# Altium schematic sheet WIFI.SchDoc
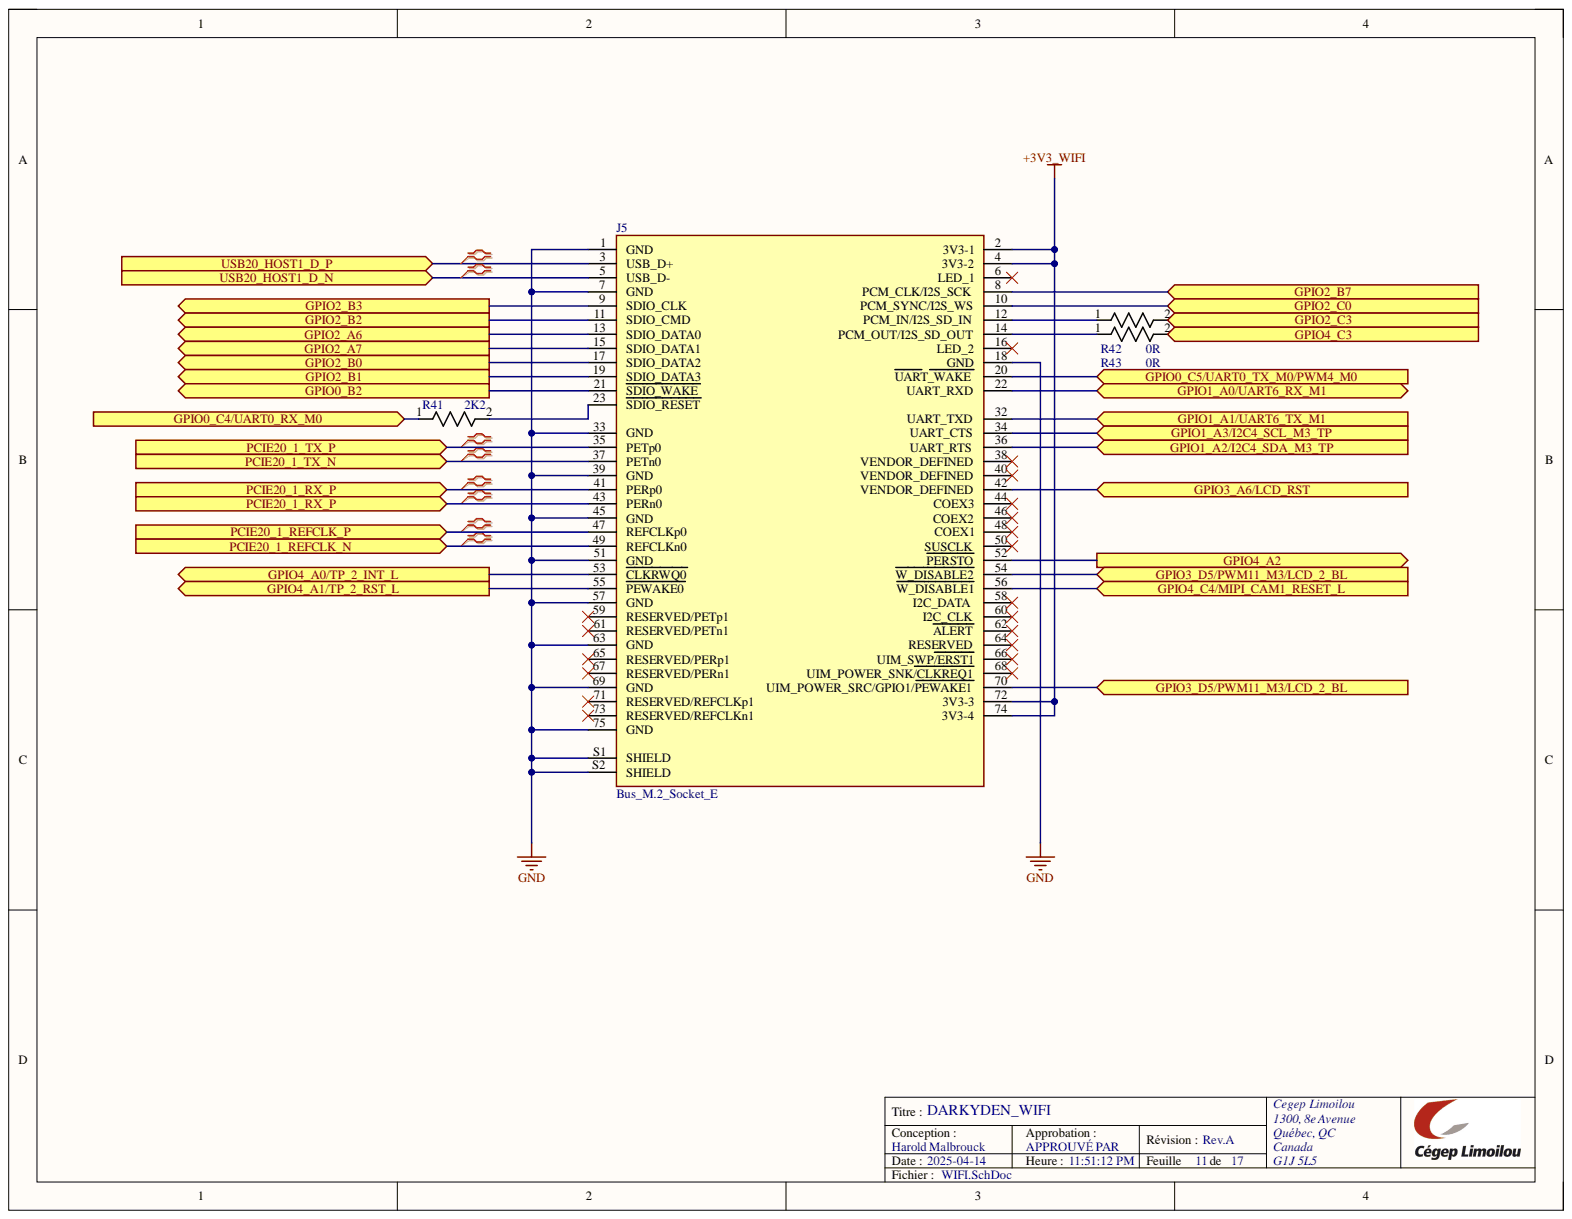
[diagram p0: WIFI.SchDoc]

## Parts
J5  Bus_M.2_Socket_E  [AMPHENOL_MDT320E01001]
R41  2K2  [RES_0816_SUS]
R42  0R  [RES_0816_SUS]
R43  0R  [RES_0816_SUS]

## Ports
GPIO0_B2, GPIO0_C4/UART0_RX_M0, GPIO0_C5/UART0_TX_M0/PWM4_M0, GPIO1_A0/UART6_RX_M1, GPIO1_A1/UART6_TX_M1, GPIO1_A2/I2C4_SDA_M3_TP, GPIO1_A3/I2C4_SCL_M3_TP, GPIO2_A6, GPIO2_A7, GPIO2_B0, GPIO2_B1, GPIO2_B2, GPIO2_B3, GPIO2_B7, GPIO2_C0, GPIO2_C3, GPIO3_A6/LCD_RST, GPIO3_D5/PWM11_M3/LCD_2_BL, GPIO4_A0/TP_2_INT_L, GPIO4_A1/TP_2_RST_L, GPIO4_A2, GPIO4_C3, GPIO4_C4/MIPI_CAM1_RESET_L, PCIE20_1_REFCLK_N, PCIE20_1_REFCLK_P, PCIE20_1_RX_P, PCIE20_1_TX_N, PCIE20_1_TX_P, USB20_HOST1_D_N, USB20_HOST1_D_P

## Nets
+3V3_WIFI: J5.2(3V3-1) J5.4(3V3-2) J5.72(3V3-3) J5.74(3V3-4)
GND: J5.1(GND) J5.7(GND) J5.18(GND) J5.33(GND) J5.39(GND) J5.45(GND) J5.51(GND) J5.57(GND) J5.63(GND) J5.69(GND) J5.75(GND) J5.S1(SHIELD) J5.S2(SHIELD)
GPIO0_B2: J5.21(SDIO_WAKE) ; port GPIO0_B2
GPIO0_C4/UART0_RX_M0: R41.1 ; port GPIO0_C4/UART0_RX_M0
GPIO0_C5/UART0_TX_M0/PWM4_M0: J5.20(UART_WAKE) ; port GPIO0_C5/UART0_TX_M0/PWM4_M0
GPIO1_A0/UART6_RX_M1: J5.22(UART_RXD) ; port GPIO1_A0/UART6_RX_M1
GPIO1_A1/UART6_TX_M1: J5.32(UART_TXD) ; port GPIO1_A1/UART6_TX_M1
GPIO1_A2/I2C4_SDA_M3_TP: J5.36(UART_RTS) ; port GPIO1_A2/I2C4_SDA_M3_TP
GPIO1_A3/I2C4_SCL_M3_TP: J5.34(UART_CTS) ; port GPIO1_A3/I2C4_SCL_M3_TP
GPIO2_A6: J5.13(SDIO_DATA0) ; port GPIO2_A6
GPIO2_A7: J5.15(SDIO_DATA1) ; port GPIO2_A7
GPIO2_B0: J5.17(SDIO_DATA2) ; port GPIO2_B0
GPIO2_B1: J5.19(SDIO_DATA3) ; port GPIO2_B1
GPIO2_B2: J5.11(SDIO_CMD) ; port GPIO2_B2
GPIO2_B3: J5.9(SDIO_CLK) ; port GPIO2_B3
GPIO2_B7: J5.8(PCM_CLK/I2S_SCK) ; port GPIO2_B7
GPIO2_C0: J5.10(PCM_SYNC/I2S_WS) ; port GPIO2_C0
GPIO2_C3: R42.2 ; port GPIO2_C3
GPIO3_A6/LCD_RST: J5.42(VENDOR_DEFINED) ; port GPIO3_A6/LCD_RST
GPIO3_D5/PWM11_M3/LCD_2_BL: J5.54(W_DISABLE2) J5.70(UIM_POWER_SRC/GPIO1/PEWAKE1) ; port GPIO3_D5/PWM11_M3/LCD_2_BL
GPIO4_A0/TP_2_INT_L: J5.53(CLKRWQ0) ; port GPIO4_A0/TP_2_INT_L
GPIO4_A1/TP_2_RST_L: J5.55(PEWAKE0) ; port GPIO4_A1/TP_2_RST_L
GPIO4_A2: J5.52(PERSTO) ; port GPIO4_A2
GPIO4_C3: R43.2 ; port GPIO4_C3
GPIO4_C4/MIPI_CAM1_RESET_L: J5.56(W_DISABLE1) ; port GPIO4_C4/MIPI_CAM1_RESET_L
PCIE20_1_REFCLK_N: J5.49(REFCLKn0) ; port PCIE20_1_REFCLK_N
PCIE20_1_REFCLK_P: J5.47(REFCLKp0) ; port PCIE20_1_REFCLK_P
PCIE20_1_RX_P: J5.41(PERp0) J5.43(PERn0) ; port PCIE20_1_RX_P
PCIE20_1_TX_N: J5.37(PETn0) ; port PCIE20_1_TX_N
PCIE20_1_TX_P: J5.35(PETp0) ; port PCIE20_1_TX_P
USB20_HOST1_D_N: J5.5(USB_D-) ; port USB20_HOST1_D_N
USB20_HOST1_D_P: J5.3(USB_D+) ; port USB20_HOST1_D_P
NetJ5_12: J5.12(PCM_IN/I2S_SD_IN) R42.1
NetJ5_14: J5.14(PCM_OUT/I2S_SD_OUT) R43.1
NetJ5_23: J5.23(SDIO_RESET) R41.2

Not connected: J5 6(LED_1) 16(LED_2) 38(VENDOR_DEFINED) 40(VENDOR_DEFINED) 44(COEX3) 46(COEX2) 48(COEX1) 50(SUSCLK) 58(I2C_DATA) 59(RESERVED/PETp1) 60(I2C_CLK) 61(RESERVED/PETn1) 62(ALERT) 64(RESERVED) 65(RESERVED/PERp1) 66(UIM_SWP/ERST1) 67(RESERVED/PERn1) 68(UIM_POWER_SNK/CLKREQ1) 71(RESERVED/REFCLKp1) 73(RESERVED/REFCLKn1)

## Library data of the parts
J5 = Bus_M.2_Socket_E: PCIe M.2 Connectors, Storage and Server Connector, P=0.5mm, H=3.2mm, Key E,30Î¼in Au Plating; MF: Amphenol ICC; MP: MDT320E01001; Availability: In Stock; Check_prices: https://www.snapeda.com/parts/MDT320E01001/Amphenol+FCI/view-part/?ref=eda; Component Kind: Standard; Library Name: Bus_M.2_Socket_E.SchLib; Package: None; Price: None; Purchase-URL: https://pricing.snapeda.com/search/part/MDT320E01001/?ref=eda; SnapEDA_Link: https://www.snapeda.com/parts/MDT320E01001/Amphenol+FCI/view-part/?ref=snap
R41-R43 = RG1608P-104-B-T5: No Description Available; Mfr_Name: Susumu; Component Kind: Standard; Library Name: RES_SMD_0603.SchLib
